# Altium schematic sheet USB and LEDs.SchDoc
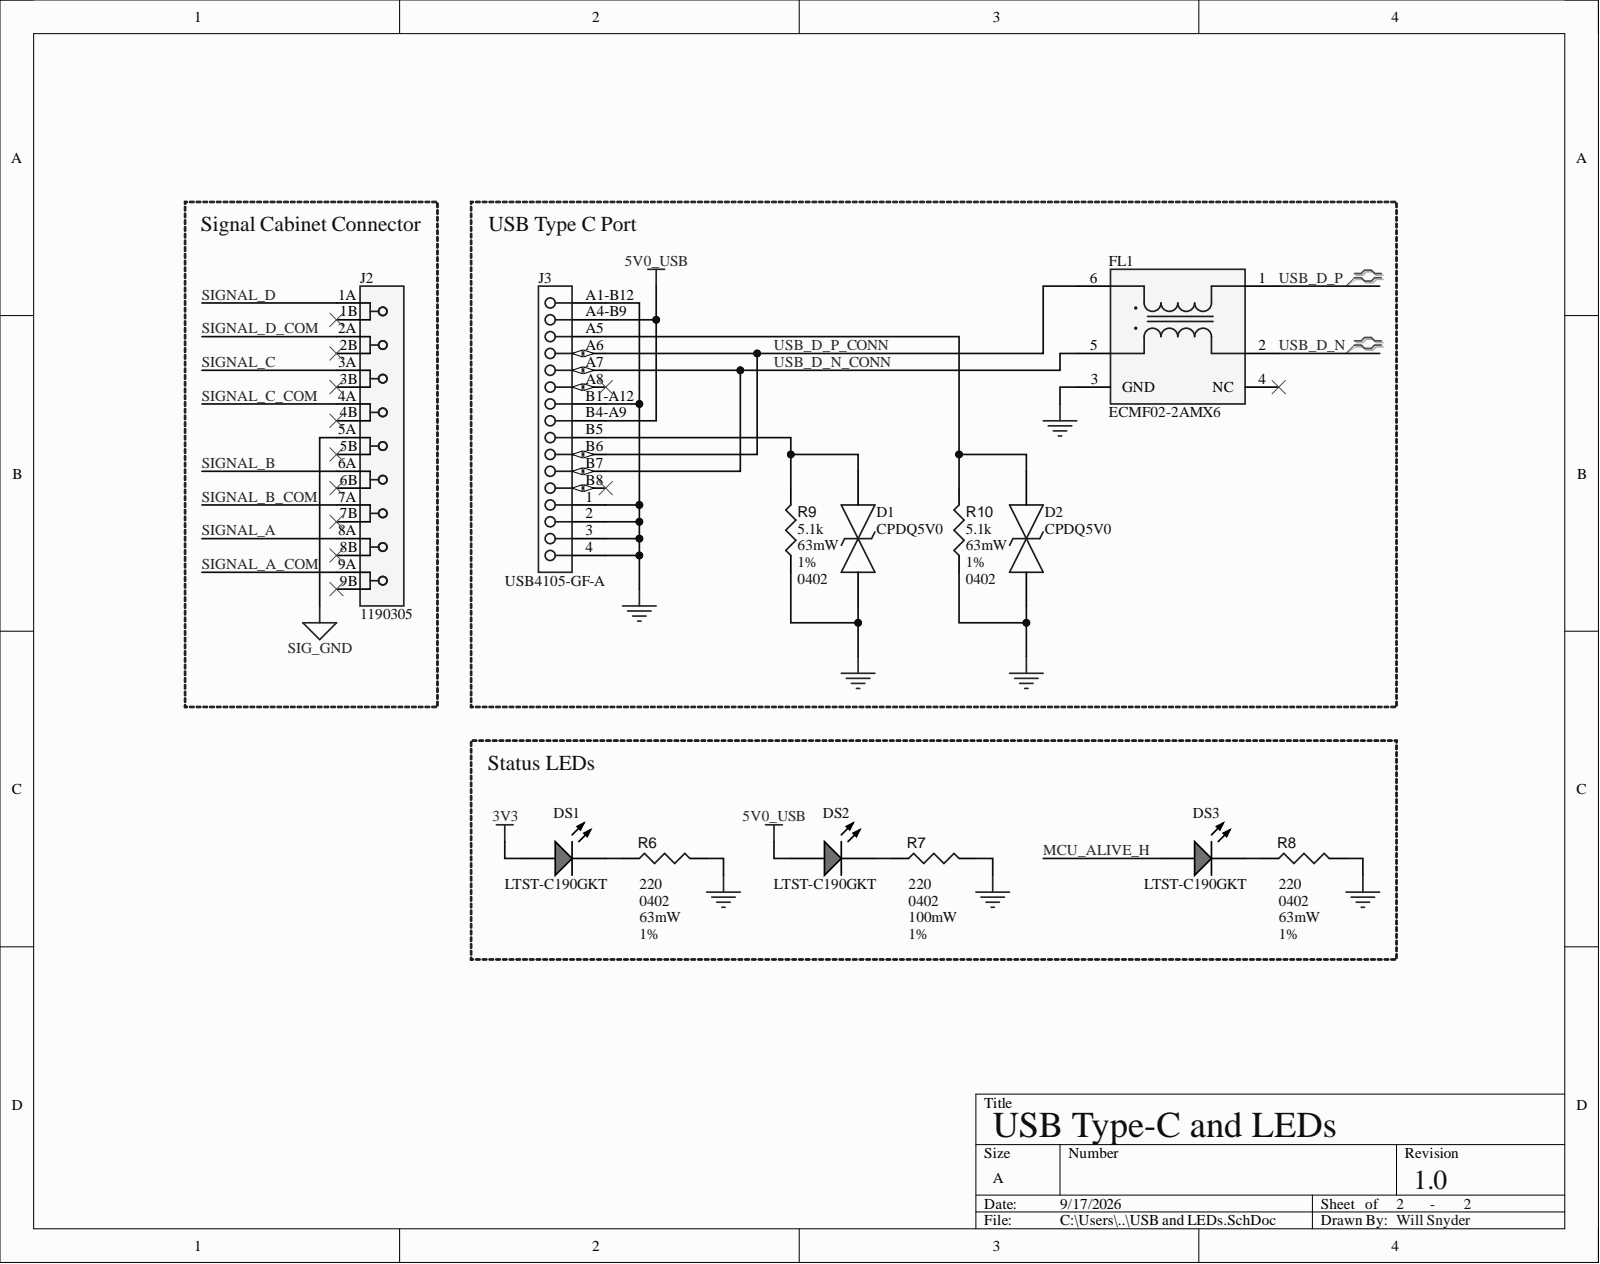
[diagram p0: USB and LEDs.SchDoc]

## Parts
D1  CPDQ5V0  [MBTB-D-SOD-923F-IMP-0402]
D2  CPDQ5V0  [MBTB-D-SOD-923F-IMP-0402]
DS1  LTST-C190GKT  [LTST-C190GKT_V]
DS2  LTST-C190GKT  [LTST-C190GKT_V]
DS3  LTST-C190GKT  [LTST-C190GKT_V]
FL1  ECMF02-2AMX6  [FP-QFN-6L-IPC_A]
J2  1190305  [PHOENIX_1190305]
J3  USB4105-GF-A  [FP-USB4105-GF-A-MFG]
R6  value 220  [RESC1005X40X25NL05T05]  (hidden comment: CRCW040259R0FKED)
R7  value 220  [RESC1005X40X25NL05T05]  (hidden comment: ERJ-2RKF1430X)
R8  value 220  [RESC1005X40X25NL05T05]  (hidden comment: CRCW040259R0FKED)
R9  value 5.1k  Case/Package: 0402  Power: 63mW  Tolerance: 1%  [RESC1005X40X25NL05T05]  (hidden comment: MCS04020C5101FE000)
R10  value 5.1k  Case/Package: 0402  Power: 63mW  Tolerance: 1%  [RESC1005X40X25NL05T05]  (hidden comment: MCS04020C5101FE000)

## Nets
3V3: DS1.1
5V0_USB: DS2.1 J3.A4-B9 J3.B4-A9
GND: D1.2 D2.2 FL1.3(GND) J3.1 J3.2 J3.3 J3.4 J3.A1-B12 J3.B1-A12 R6.2 R7.2 R8.2 R9.2 R10.2
SIG_GND: J2.5A
MCU_ALIVE_H: DS3.1
SIGNAL_A: J2.8A
SIGNAL_A_COM: J2.9A
SIGNAL_B: J2.6A
SIGNAL_B_COM: J2.7A
SIGNAL_C: J2.3A
SIGNAL_C_COM: J2.4A
SIGNAL_D: J2.1A
SIGNAL_D_COM: J2.2A
USB_D_N: FL1.2
USB_D_N_CONN: FL1.5 J3.A7 J3.B7
USB_D_P: FL1.1
USB_D_P_CONN: FL1.6 J3.A6 J3.B6
NetD1_1: D1.1 J3.B5 R9.1
NetD2_1: D2.1 J3.A5 R10.1
NetDS1_2: DS1.2 R6.1
NetDS2_2: DS2.2 R7.1
NetDS3_2: DS3.2 R8.1

Not connected: FL1 4(NC); J2 1B 2B 3B 4B 5B 6B 7B 8B 9B; J3 A8 B8

## Library data of the parts
D1 D2 = CMP-004-00042-2: 18.6V Clamp 9.4A (8/20Âµs) Ipp Tvs Diode Surface Mount 0402/SOD-923F; MF: Comchip Technology; MP: CPDQ5V0-HF; Availability: Good; Case/Package: 0402 Comchip; Check_prices: https://www.snapeda.com/parts/CPDQ5V0-HF/Comchip%20Technology/view-part/809934/?ref=eda; Component Kind: Standard; Component Type: Standard; Design Item ID: CPDQ5V0-HF; Library Name: Personal Workspace; Pins: 2; Price: None; Purchase-URL: https://pricing.snapeda.com/search/part/CPDQ5V0-HF/?ref=eda; SnapEDA_Link: https://www.snapeda.com/parts/CPDQ5V0-HF/Comchip%20Technology/view-part/809934/?ref=snap; Source: CPDQ5V0-HF.SchLib
DS1-DS3 = CMP-007-00022-2: LED Uni-Color Green, 100 mW, 30 mA, -55 to 85 degC, 2-Pin SMD, RoHS, Tape and Reel; Manufacturer: Lite-On; Forward Current: 20mA; Forward Voltage: 2.1V; Lens Style: Clear; Luminous Intensity: 6mcd; Power: 100mW; Viewing Angle: 130degrees; Case/Package: 0603; Colour: Green; Component Kind: Standard; Datasheet URL: http://datasheet.octopart.com/LTST-C190GKT-Lite-On-datasheet-158530.pdf; Datasheet Version: Dec-06; Illumination Colour: Green; Library Name: Personal Workspace; Manufacturer URL: http://www.us.liteon.com/; Max Operating Temperature: 85°C; Min Operating Temperature: -55°C; Mounting Technology: SM; Nominal Vf: 2.1V; Package Description: 2-Pin Surface Mount Device, Body 1.6 x 0.8 mm; Package Quantity: 3000; Package Reference: C190GKT; Packaging: TapeandReel; Pins: 2; RoHS Compliant: True
FL1 = CMP-00030-00005-1: Data Line Filter, 2 Function(s), 6 Pin(s), Plastic; Component Kind: Standard; Library Name: Personal Workspace; Max Operating Temperature: 85°C; Min Operating Temperature: -40°C; Mounting Technology: Surface Mount; Pins: 6; RoHS Compliant: Yes
J2 = CMP-106-000000-2: LPT 2,5/ 9-5,0; MF: Phoenix Contact; Check_prices: https://www.snapeda.com/parts/1190305/Phoenix+Contact/view-part/?ref=eda; Component Kind: Standard; Component Type: Standard; Design Item ID: 1190305; Library Name: Personal Workspace; MP: 1190305; Package: None; Pin Count: 18; SnapEDA_Link: https://www.snapeda.com/parts/1190305/Phoenix+Contact/view-part/?ref=snap; Source: 1190305.SchLib
J3 = CMP-002-00095-3: Conn USB 2.0 Type C RCP 24 POS 0.3mm/0.5mm Solder RA SMD 24 Terminal 1 Port T/R; Component Kind: Standard; Library Name: Personal Workspace
R6 R8 = CMP-009-00207-1: Fixed Resistor, Metal Glaze/thick Film, 0.063W, 59ohm, 50V, 1% +/-Tol, 100ppm/Cel, Surface Mount, 0402; Power: 63mW; Case/Package: 0402; Component Kind: Standard; Library Name: Personal Workspace; Max Operating Temperature: 155°C; Min Operating Temperature: -55°C; Mounting Technology: Surface Mount; Pins: 2; RoHS Compliant: Yes; Tolerance: 1%
R7 = CMP-009-00208-1: Fixed Resistor, Metal Glaze/thick Film, 0.1W, 143ohm, 50V, 1% +/-Tol, 100ppm/Cel, Surface Mount, 0402; Power: 100mW; Case/Package: 0402; Component Kind: Standard; Library Name: Personal Workspace; Max Operating Temperature: 155°C; Min Operating Temperature: -55°C; Mounting Technology: Surface Mount; Pins: 2; RoHS Compliant: Yes; Tolerance: 1%
R9 R10 = CMP-009-00205-1: Fixed Resistor, Thin Film, 0.063W, 5100ohm, 50V, 1% +/-Tol, 50ppm/Cel, Surface Mount, 0402; Component Kind: Standard; Library Name: Personal Workspace; Max Operating Temperature: 125°C; Min Operating Temperature: -55°C; Mounting Technology: Surface Mount; Pins: 2; RoHS Compliant: Yes
